# Altium schematic sheet MCU.SchDoc(MCU)
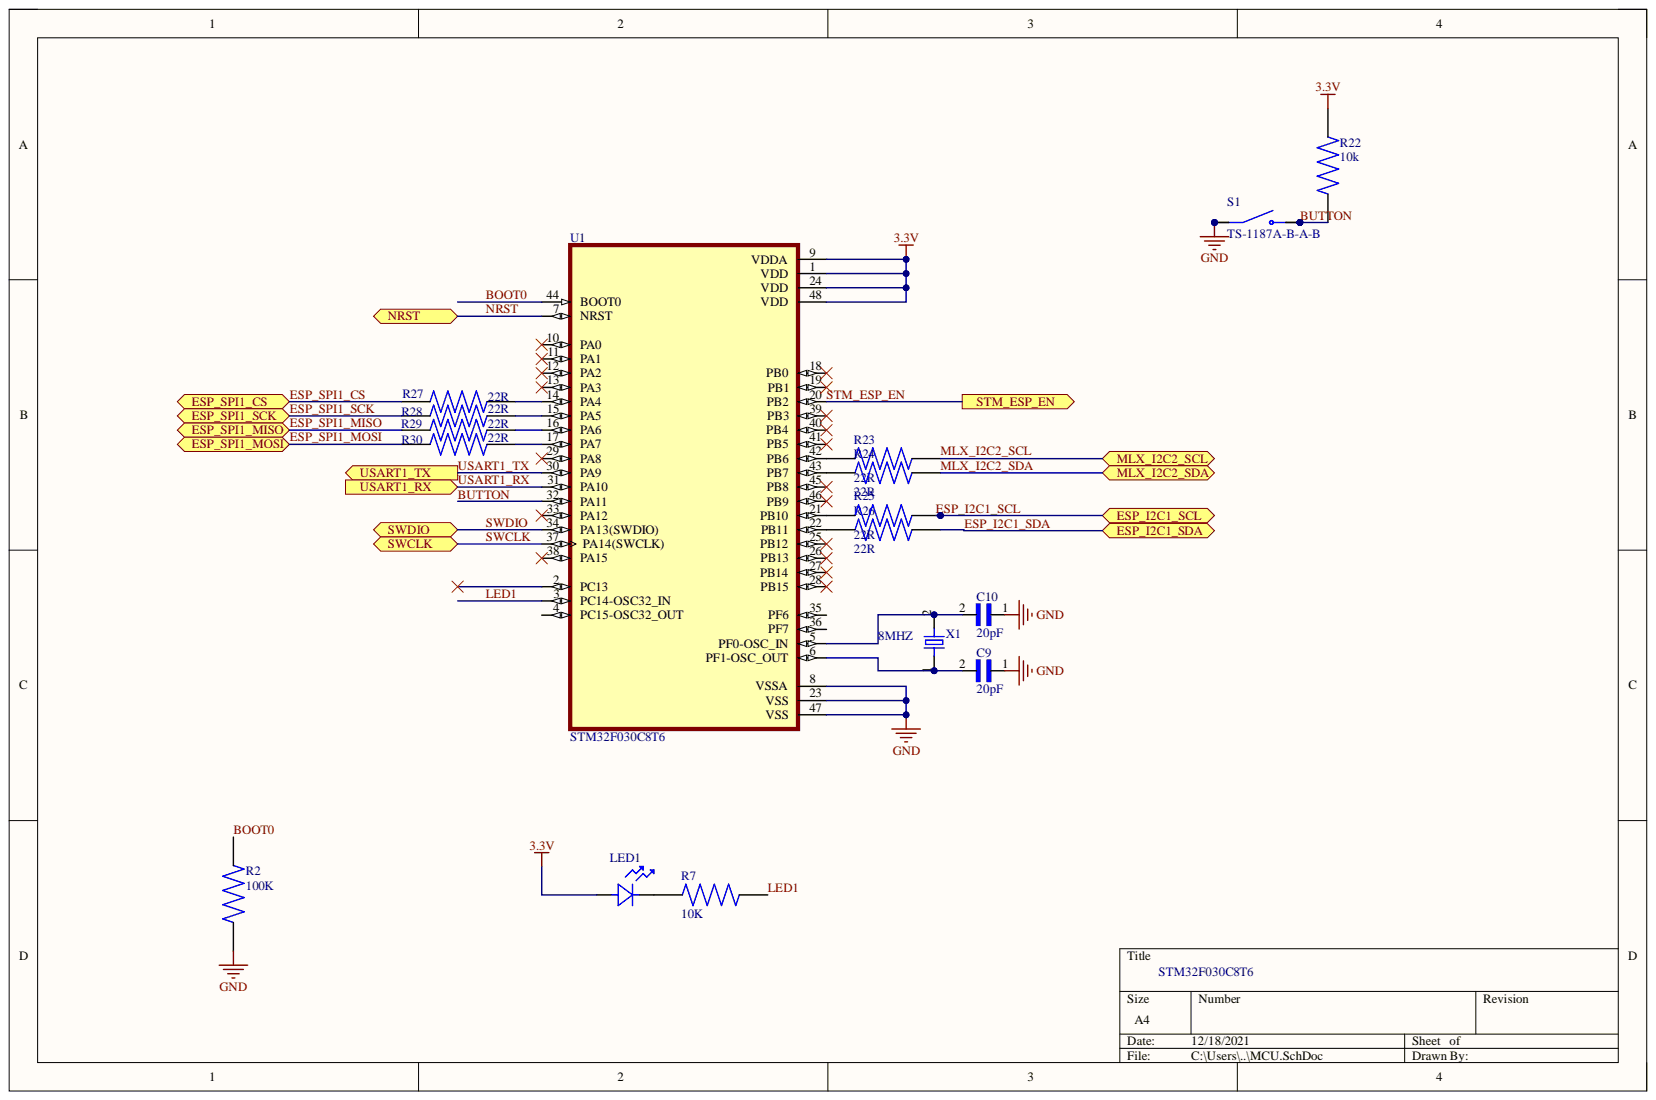
[diagram p0: MCU.SchDoc]

## Parts
C9  20pF  [CAPC1005X55N]
C10  20pF  [CAPC1005X55N]
LED1  CMD17-21VRC_TR8  [LED_CMD17-21VRC_TR8]  (value not drawn)
R2  100K  [RESC1005X35N]
R7  10K  [RESC1005X35N]
R22  10k  [RESC1005X35N]
R23  22R  [RESC1005X35N]
R24  22R  [RESC1005X35N]
R25  22R  [RESC1005X35N]
R26  22R  [RESC1005X35N]
R27  22R  [RESC1005X35N]
R28  22R  [RESC1005X35N]
R29  22R  [RESC1005X35N]
R30  22R  [RESC1005X35N]
S1  TS-1187A-B-A-B  [SW_TS-1187A-B-A-B]
U1  STM32F030C8T6  [QFP50P900X900X160-48N]
X1  8MHZ  [XTAL500X320X130N]

## Ports
ESP_I2C1_SCL, ESP_I2C1_SDA, ESP_SPI1_CS, ESP_SPI1_MISO, ESP_SPI1_MOSI, ESP_SPI1_SCK, MLX_I2C2_SCL, MLX_I2C2_SDA, NRST, STM_ESP_EN, SWCLK, SWDIO, USART1_RX, USART1_TX

## Nets
3.3V: LED1.1 R22.2 U1.1(VDD) U1.9(VDDA) U1.24(VDD) U1.48(VDD)
GND: C9.1 C10.1 R2.1 S1.A S1.B U1.8(VSSA) U1.23(VSS) U1.47(VSS)
BOOT0: R2.2 U1.44(BOOT0)
BUTTON: R22.1 S1.C S1.D U1.32(PA11)
ESP_I2C1_SCL: R25.2 ; port ESP_I2C1_SCL
ESP_I2C1_SDA: R26.2 ; port ESP_I2C1_SDA
ESP_SPI1_CS: R27.1 ; port ESP_SPI1_CS
ESP_SPI1_MISO: R29.1 ; port ESP_SPI1_MISO
ESP_SPI1_MOSI: R30.1 ; port ESP_SPI1_MOSI
ESP_SPI1_SCK: R28.1 ; port ESP_SPI1_SCK
LED1: R7.2 U1.3(PC14-OSC32_IN)
MLX_I2C2_SCL: R23.2 ; port MLX_I2C2_SCL
MLX_I2C2_SDA: R24.2 ; port MLX_I2C2_SDA
NRST: U1.7(NRST) ; port NRST
STM_ESP_EN: U1.20(PB2) ; port STM_ESP_EN
SWCLK: U1.37(PA14(SWCLK)) ; port SWCLK
SWDIO: U1.34(PA13(SWDIO)) ; port SWDIO
USART1_RX: U1.31(PA10) ; port USART1_RX
USART1_TX: U1.30(PA9) ; port USART1_TX
NetC9_2: C9.2 U1.6(PF1-OSC_OUT) X1.1
NetC10_2: C10.2 U1.5(PF0-OSC_IN) X1.2
NetLED1_2: LED1.2 R7.1
NetR23_1: R23.1 U1.42(PB6)
NetR24_1: R24.1 U1.43(PB7)
NetR25_1: R25.1 U1.21(PB10)
NetR26_1: R26.1 U1.22(PB11)
NetR27_2: R27.2 U1.14(PA4)
NetR28_2: R28.2 U1.15(PA5)
NetR29_2: R29.2 U1.16(PA6)
NetR30_2: R30.2 U1.17(PA7)

Not connected: U1 2(PC13) 4(PC15-OSC32_OUT) 10(PA0) 11(PA1) 12(PA2) 13(PA3) 18(PB0) 19(PB1) 25(PB12) 26(PB13) 27(PB14) 28(PB15) 29(PA8) 33(PA12) 35(PF6) 36(PF7) 38(PA15) 39(PB3) 40(PB4) 41(PB5) 45(PB8) 46(PB9)

## Library data of the parts
C9 C10 = CL05B104KO5NNNC: 0.1ÂµF Â±10% 16V Ceramic Capacitor X7R 0402 _1005 Metric_; MF: Samsung Electro-Mechanics; Availability: Unavailable; Component Kind: Standard; Library Name: CL05B104KO5NNNC.IntLib; MP: CL05B104KO5NNNC; Package: 0402 Samsung; Price: None; SnapEDA_Link: https://www.snapeda.com/parts/CL05B104KO5NNNC/Samsung%20Electro-Mechanics/view-part/3598311/?ref=snap
LED1 = CMD17-21VRC_TR8: KÄ±rmÄ±zÄ± LED GÃ¶stergesi - AyrÄ±k 2V 0805 (2012 Metrik); MF: Visual; MP: CMD17-21VRC/TR8; Availability: Unavailable; Component Kind: Standard; DigiKey-Purchase-URL: https://snapeda.com/shop?store=DigiKey&id=570104; Library Name: CMD17-21VRC_TR8.IntLib; Package: 0805 VCC; Price: None; SnapEDA_Link: https://www.snapeda.com/parts/CMD17-21VRC/TR8/Visual%20Communications%20Company%20-%20VCC/view-part/570104/?ref=snap
R2 R7 R22-R30 = RN73C1E1K24BTDF: RN 0402 1K24 0.1% 10PPM 1K RL; Availability: Unavailable; Component Kind: Standard; Library Name: RN73C1E1K24BTDF.IntLib; MP: RN73C1E1K24BTDF; Package: None; Price: None
S1 = TS-1187A-B-A-B: Tact Switch; MF: XKB Industrial Precision; Availability: Unavailable; Component Kind: Standard; Library Name: Button-JLCPCB-TS-1187A-B-A-B.IntLib; MP: TS-1187A-B-A-B; Package: Package; Price: None; SnapEDA_Link: https://www.snapeda.com/parts/TS-1187A-B-A-B/XKB%20Industrial%20Precision/view-part/4258190/?ref=snap
U1 = STM32F030C8T6: ARMÂ® CortexÂ®-M0 STM32F0 Microcontroller IC 32-Bit 48MHz 64KB _64K x 8_ FLASH; MF: STMicroelectronics; Availability: Unavailable; Component Kind: Standard; Library Name: STM32F030C8T6.IntLib; MP: STM32F030C8T6; Package: LQFP-48 STMicroelectronics; Price: None; SnapEDA_Link: https://www.snapeda.com/parts/STM32F030C8T6/STMicroelectronics/view-part/137606/?ref=snap
X1 = ABM3-8.000MHZ-D2Y-T: 8MHz Â±20ppm Crystal 18pF 140 Ohms 2-SMD, No Lead; MF: Abracon; Component Kind: Standard; Digi-Key_Part_Number: 535-10630-1-ND; Digi-Key_Purchase_URL: https://www.digikey.com/product-detail/en/abracon-llc/ABM3-8.000MHZ-D2Y-T/535-10630-1-ND/2344632?utm_source=snapeda&utm_medium=aggregator&utm_campaign=symbol; MP: ABM3-8.000MHZ-D2Y-T; Package: SMD-2 Abracon; SnapEDA_Link: https://www.snapeda.com/parts/ABM3-8.000MHZ-D2Y-T/Abracon%20LLC/view-part/106372/?ref=snap; Library Name: Cristal-ABM3-8.000MHZ-D2Y-T.IntLib
